# Altium schematic sheet ST_LINK-Wiki.SchDoc
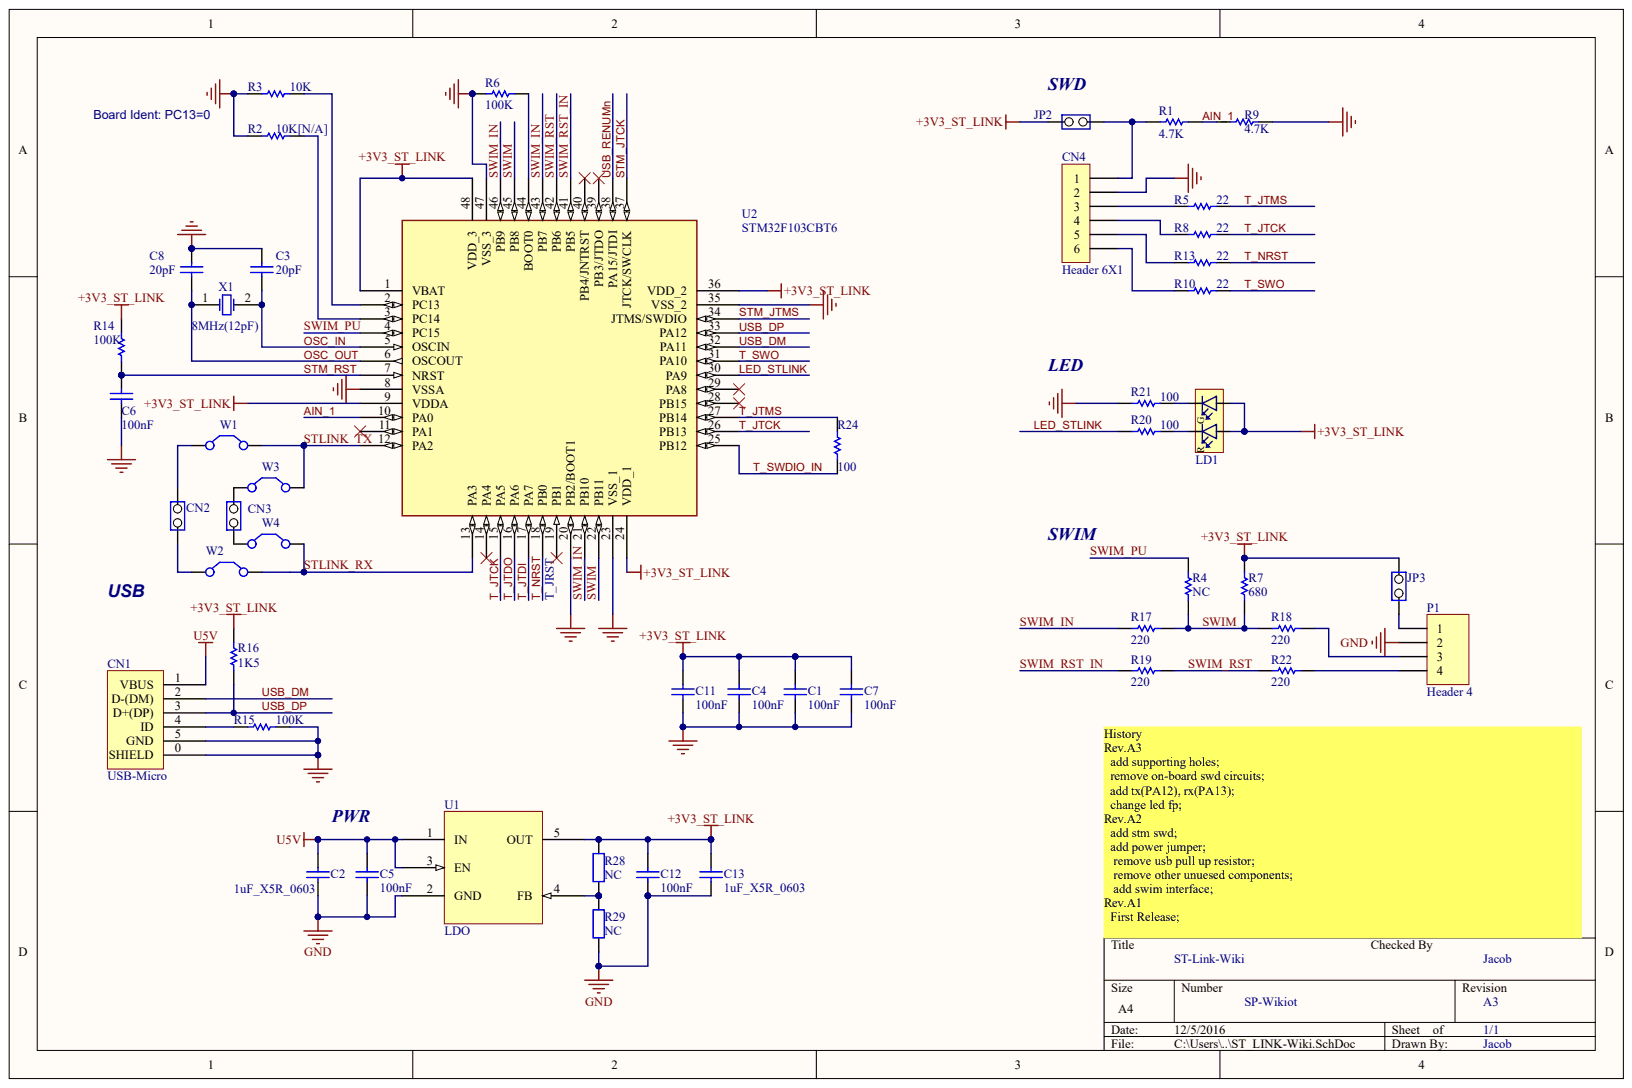
[diagram p0: ST_LINK-Wiki.SchDoc]

## Parts
C1  100nF
C2  1uF_X5R_0603
C3  20pF
C4  100nF
C5  100nF
C6  100nF
C7  100nF
C8  20pF
C11  100nF
C12  100nF
C13  1uF_X5R_0603
CN1  USB-Micro
CN2  (no value)
CN3  (no value)
CN4  Header 6X1
JP2  (no value)
JP3  (no value)
LD1  LTST-C195KGJRKT  [LED_DUAL_0606]  (value not drawn)
P1  Header 4
R1  4.7K
R2  10K[N/A]
R3  10K
R4  NC
R5  22
R6  100K
R7  680
R8  22
R9  4.7K
R10  22
R13  22
R14  100K
R15  100K
R16  1K5
R17  220
R18  220
R19  220
R20  100
R21  100
R22  220
R24  100
R28  NC
R29  NC
U1  LDO
U2  STM32F103CBT6  [QFP48 7X7]
W1  Jumper2-1  (value not drawn)
W2  Jumper2-1  (value not drawn)
W3  Jumper2-1  (value not drawn)
W4  Jumper2-1  (value not drawn)
X1  8MHz(12pF)

## Nets
+3V3_ST_LINK: C1.2 C4.2 C7.2 C11.2 C12.2 C13.1 JP2.1 JP3.1 LD1.3 LD1.4 R7.2 R14.2 R16.2 R28.1 U1.5(OUT) U2.1(VBAT) U2.9(VDDA) U2.24(VDD_1) U2.36(VDD_2) U2.48(VDD_3)
GND: C1.1 C2.1 C3.2 C4.1 C5.1 C6.1 C7.1 C8.2 C11.1 C12.1 C13.2 CN1.0(SHIELD) CN1.5(GND) CN4.2 P1.2 R2.1 R3.1 R6.1 R9.2 R15.2 R21.1 R29.1 U1.2(GND) U2.8(VSSA) U2.20(PB2/BOOT1) U2.23(VSS_1) U2.35(VSS_2) U2.47(VSS_3)
U5V: C2.2 C5.2 CN1.1(VBUS) U1.1(IN) U1.3(EN)
AIN_1: R1.1 R9.1 U2.10(PA0)
LED_STLINK: R20.1 U2.30(PA9)
OSC_IN: C3.1 U2.5(OSCIN) X1.2
OSC_OUT: C8.1 U2.6(OSCOUT) X1.1
STLINK_RX: U2.13(PA3) W2.2 W4.2
STLINK_TX: U2.12(PA2) W1.2 W3.2
STM_JTCK: U2.37(JTCK/SWCLK)
STM_JTMS: U2.34(JTMS/SWDIO)
STM_RST: C6.2 R14.1 U2.7(NRST)
SWIM: R4.1 R7.1 R17.2 R18.1 U2.22(PB11) U2.45(PB8)
SWIM_IN: R17.1 U2.21(PB10) U2.43(PB7) U2.46(PB9)
SWIM_PU: R4.2 U2.4(PC15)
SWIM_RST: R19.2 R22.1 U2.42(PB6)
SWIM_RST_IN: R19.1 U2.41(PB5)
T_JTCK: R8.2 U2.15(PA5) U2.26(PB13)
T_JTDI: U2.17(PA7)
T_JTDO: U2.16(PA6)
T_JTMS: R5.2 R24.2 U2.27(PB14)
T_NRST: R13.2 U2.18(PB0)
T_SWDIO_IN: R24.1 U2.25(PB12)
T_SWO: R10.2 U2.31(PA10)
USB_DM: CN1.2(D-(DM)) U2.32(PA11)
USB_DP: CN1.3(D+(DP)) R16.1 U2.33(PA12)
USB_RENUMN: U2.38(PA15/JTDI)
NetCN1_4: CN1.4(ID) R15.1
NetCN2_1: CN2.1 W2.1
NetCN2_2: CN2.2 W1.1
NetCN3_1: CN3.1 W4.1
NetCN3_2: CN3.2 W3.1
NetCN4_1: CN4.1 JP2.2 R1.2
NetCN4_3: CN4.3 R5.1
NetCN4_4: CN4.4 R8.1
NetCN4_5: CN4.5 R13.1
NetCN4_6: CN4.6 R10.1
NetJP3_2: JP3.2 P1.1
NetLD1_1: LD1.1 R21.2
NetLD1_2: LD1.2 R20.2
NetP1_3: P1.3 R18.2
NetP1_4: P1.4 R22.2
NetR2_2: R2.2 U2.3(PC14)
NetR3_2: R3.2 U2.2(PC13)
NetR6_2: R6.2 U2.44(BOOT0)
NetR28_2: R28.2 R29.2 U1.4(FB)

Not connected: U2 11(PA1) 14(PA4) 19(PB1) 28(PB15) 29(PA8) 39(PB3/JTDO) 40(PB4/JNTRST)

## Library data of the parts
C2 C13 = (no symbol name): Value: 1礔; Rated voltage: 25V; Tolerance: 10%; Manufacturer: *; Manufacturer P/N: *; Order code Farnell: 1288202RL; Power: *; Technology: X5R; Temperature  Factor: *; Temperature Max: +125癈; Temperature Min: -55癈; STS Code: *
C3 C8 = (no symbol name): Value: 100nF; Revision: July-2002: Re-released for DXP Platform.
CN2 CN3 = (no symbol name): Fitted: NO
LD1 = (no symbol name): Manufacturer: Lite-On Inc; 2nd source part number: 859-LTST-C195KGJRKT; Note: HSMF-A203- xxxxx GaP Red GaP Emerald Green; Artikkelnr Noca: 13019145; Part Number: LTST-C195KGJRKT; Manufacturer Part Number: LTST-C195KGJRKT; Datasheet: http://media.digikey.com/pdf/Data%20Sheets/Lite-On%20PDFs/LTST-C195KGJRKT.pdf; LatestRevisionDate: 2012-07-05; Pin Count: 4; Published: 2012-07-05; Value: LTST-C195KGJRKT; Supplier: Digi-Key; Supplier part number: 160-1452-1-ND; 2nd source Supplier: Mouser; Publisher: Nordic Semiconductor
R1 R4-R10 R13-R22 R24 = (no symbol name): Value: 10K
R2 = (no symbol name): Value: 10K; Fitted: NO
U2 = (no symbol name): Manuf_Part_1: STM32F103C8T6; Manuf_1: STM; Ref St: 9175981; Provided: ST; ECCN_US/EU: 3A991A2/NEC; Distrib: ST; Order: STM32F103C8T6
C1 C4-C7 C11 C12 CN1 CN4 JP2 JP3 P1 R3 R28 R29 U1 W1-W4 X1 = (no symbol name)
  C1 C4-C7 C11 C12: Revision: July-2002: Re-released for DXP Platform.; Value: 100nF
  CN4 P1: LatestRevisionDate: 17-Jul-2002; LatestRevisionNote: Re-released for DXP Platform.
  R3: Value: 10K
  R28 R29: Published: 8-Jun-2000; LatestRevisionDate: 17-Jul-2002; LatestRevisionNote: Re-released for DXP Platform.; PackageReference: AXIAL-0.4; Value: NC
  W1-W4: Published: 24-Mar-1999; LatestRevisionDate: 17-Jul-2002; LatestRevisionNote: Re-released for DXP Platform.; PackageReference: RAD-0.2
  X1: Published: 8-Jun-2000; LatestRevisionDate: 17-Jul-2002; LatestRevisionNote: Re-released for DXP Platform.; PackageReference: R38
  CN4 P1 R28 R29 W1-W4 X1: Publisher: Altium Limited
